# Altium schematic sheet SlimTx.SchDoc(SlimTx)
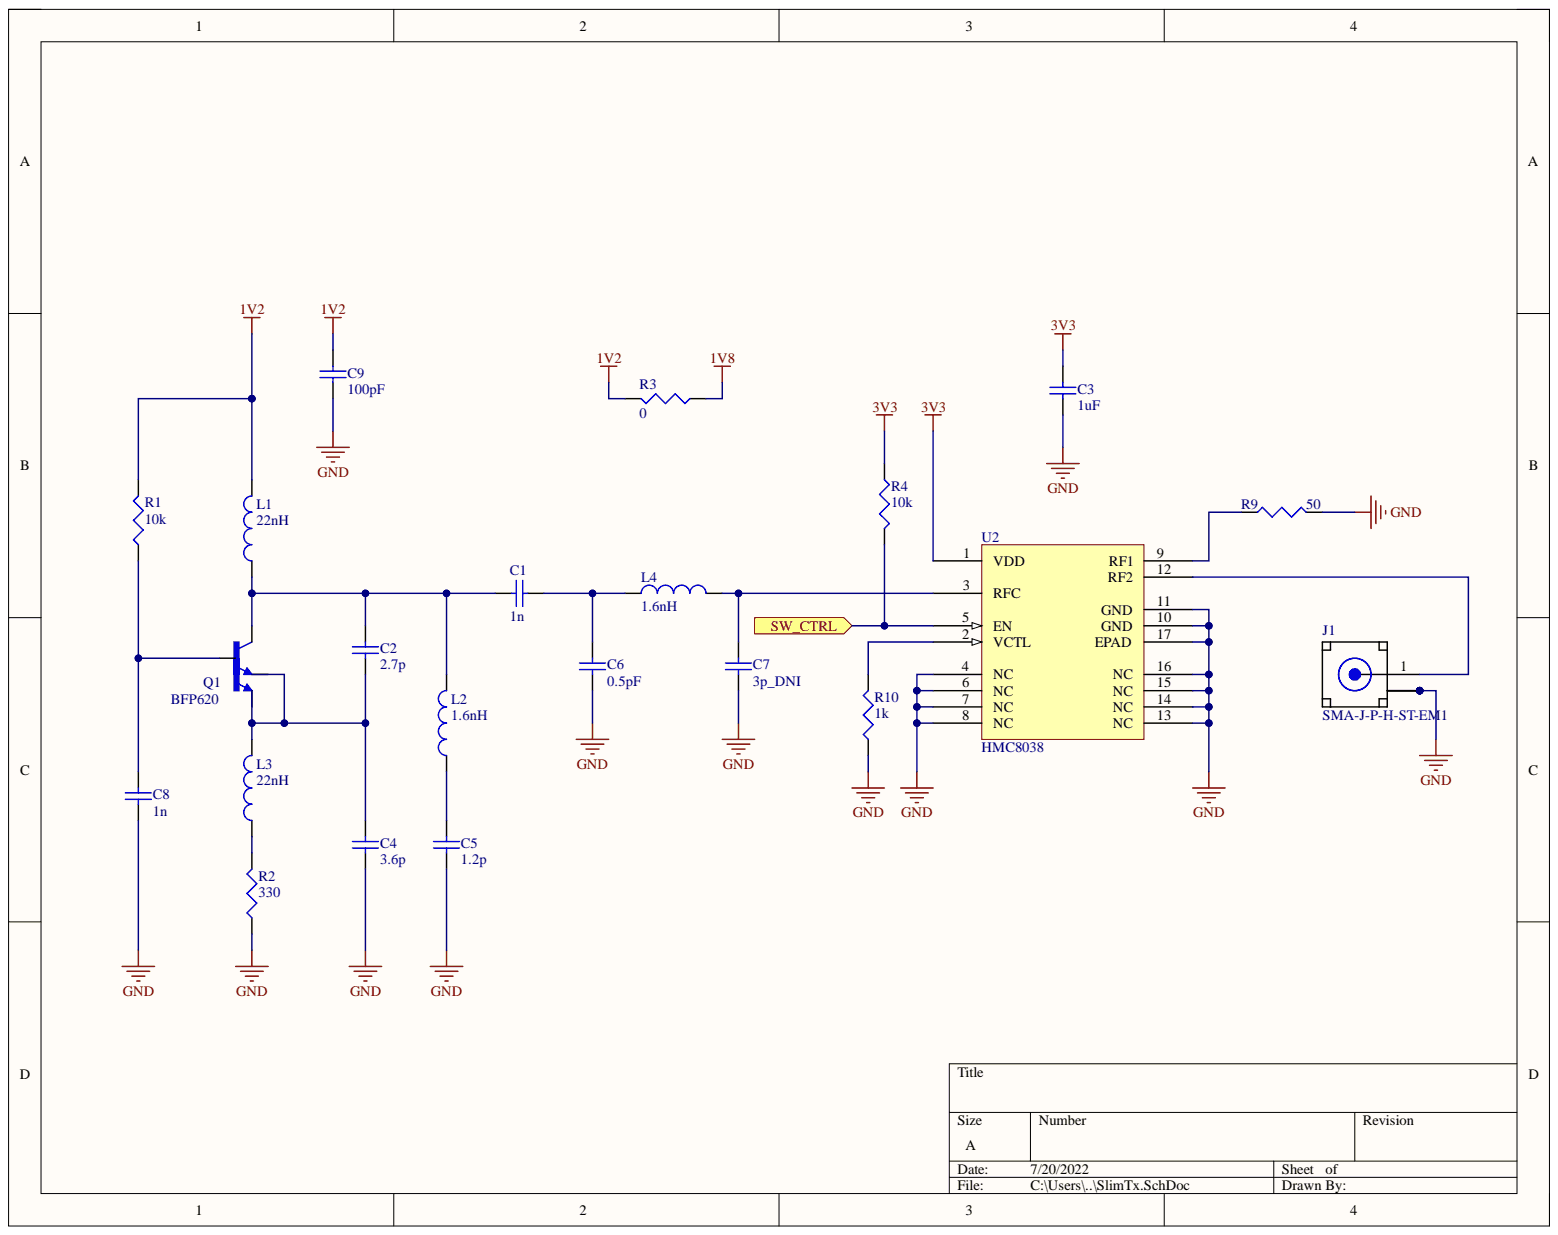
[diagram p0: SlimTx.SchDoc]

## Parts
C1  1n  [SMD-0603]
C2  2.7p  [SMD-0603]
C3  1uF  [SMD-0603]
C4  3.6p  [SMD-0603]
C5  1.2p  [SMD-0603]
C6  0.5pF  [SMD-0603]
C7  3p_DNI  [SMD-0603]
C8  1n  [SMD-0603]
C9  100pF  [SMD-0603]
J1  SMA-J-P-H-ST-EM1  [SMA-J-P-H-ST-EM1]
L1  22nH  [SMD-0603]
L2  1.6nH  [SMD-0603]
L3  22nH  [SMD-0603]
L4  1.6nH  [SMD-0603]
Q1  BFP620  [SOT343]
R1  10k  [SMD-0603]
R2  330  [SMD-0603]
R3  0  [SMD-0603]
R4  10k  [SMD-0603]
R9  50  [SMD-0603]
R10  1k  [SMD-0603]
U2  HMC8038  [PQFN127P600-8N LFCSP-17]

## Ports
SW_CTRL

## Nets
1V2: C9.2 L1.2 R1.2 R3.2
1V8: R3.1
3V3: C3.2 R4.1 U2.1(VDD)
GND: C3.1 C4.1 C5.1 C6.1 C7.1 C8.1 C9.1 J1.2_1 J1.2_2 J1.3_1 J1.3_2 R2.1 R9.2 R10.1 U2.4(NC) U2.6(NC) U2.7(NC) U2.8(NC) U2.10(GND) U2.11(GND) U2.13(NC) U2.14(NC) U2.15(NC) U2.16(NC) U2.17(EPAD)
SW_CTRL: R4.2 U2.5(EN) ; port SW_CTRL
NetC1_1: C1.1 C6.2 L4.1
NetC1_2: C1.2 C2.2 L1.1 L2.2 Q1.3
NetC2_1: C2.1 C4.2 L3.2 Q1.2 Q1.4
NetC5_2: C5.2 L2.1
NetC7_2: C7.2 L4.2 U2.3(RFC)
NetC8_2: C8.2 Q1.1 R1.1
NetJ1_1: J1.1 U2.12(RF2)
NetL3_1: L3.1 R2.2
NetR9_1: R9.1 U2.9(RF1)
NetR10_2: R10.2 U2.2(VCTL)

## Library data of the parts
C1-C9 = CAP0603: Ceramic Capacitor 0603; Component Kind: Standard; Library Name: LCR.SchLib
J1 = SMA-J-P-H-ST-EM1: Connector SMA F 50Ohm Solder ST Edge Mount Gold Over Nickel Tray; MF: Samtec; Availability: Unavailable; Component Kind: Standard; Library Name: Connectors.SchLib; MP: SMA-J-P-H-ST-EM1; Package: None; Price: None; SnapEDA_Link: https://www.snapeda.com/parts/SMA-J-P-H-ST-EM1/Samtec%20Inc./view-part/?ref=snap
L1-L4 = L0603: Inductor 0603; Component Kind: Standard; Library Name: LCR.SchLib
Q1 = BFP620: Trans RF BJT NPN 2.3V 0.08A 185mW Automotive 4-Pin(3+Tab) SOT-343 T/R; MF: Infineon Technologies; Availability: In Stock; Check_prices: https://www.snapeda.com/parts/BFP620/Infineon+Technologies/view-part/?ref=eda; Component Kind: Standard; Library Name: BFP620.IntLib; MP: BFP620; Package: None; Price: None; Purchase-URL: https://pricing.snapeda.com/search/part/BFP620/?ref=eda; SnapEDA_Link: https://www.snapeda.com/parts/BFP620/Infineon+Technologies/view-part/?ref=snap
R1-R4 R9 R10 = RES0603: Resistor-0603; Component Kind: Standard; Library Name: LCR.SchLib
U2 = HMC8038: High Isolation, Silicon SPDT, Nonreflective Switch, 0.1 GHz to 6.0 GHz; Component Kind: Standard; Library Name: HMC8038.IntLib
